# Altium schematic sheet IR_LED.SchDoc
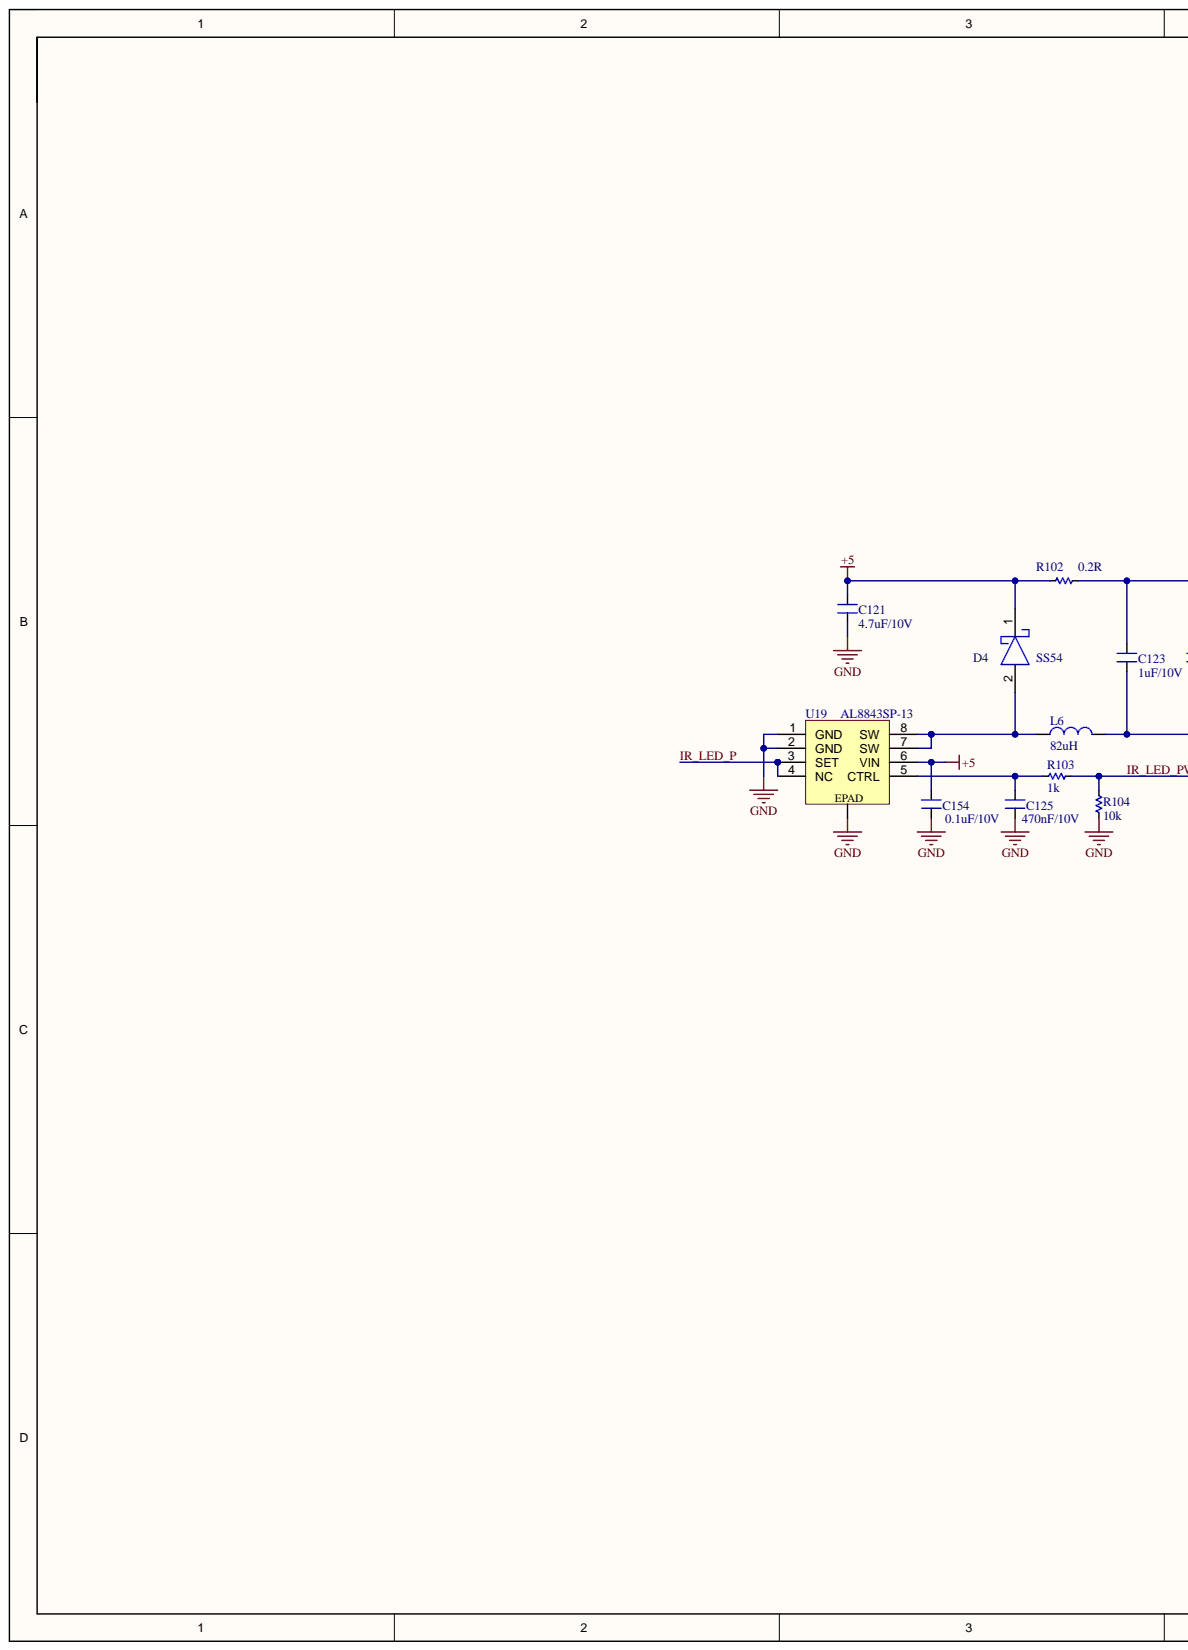
[diagram p0: IR_LED.SchDoc, left]
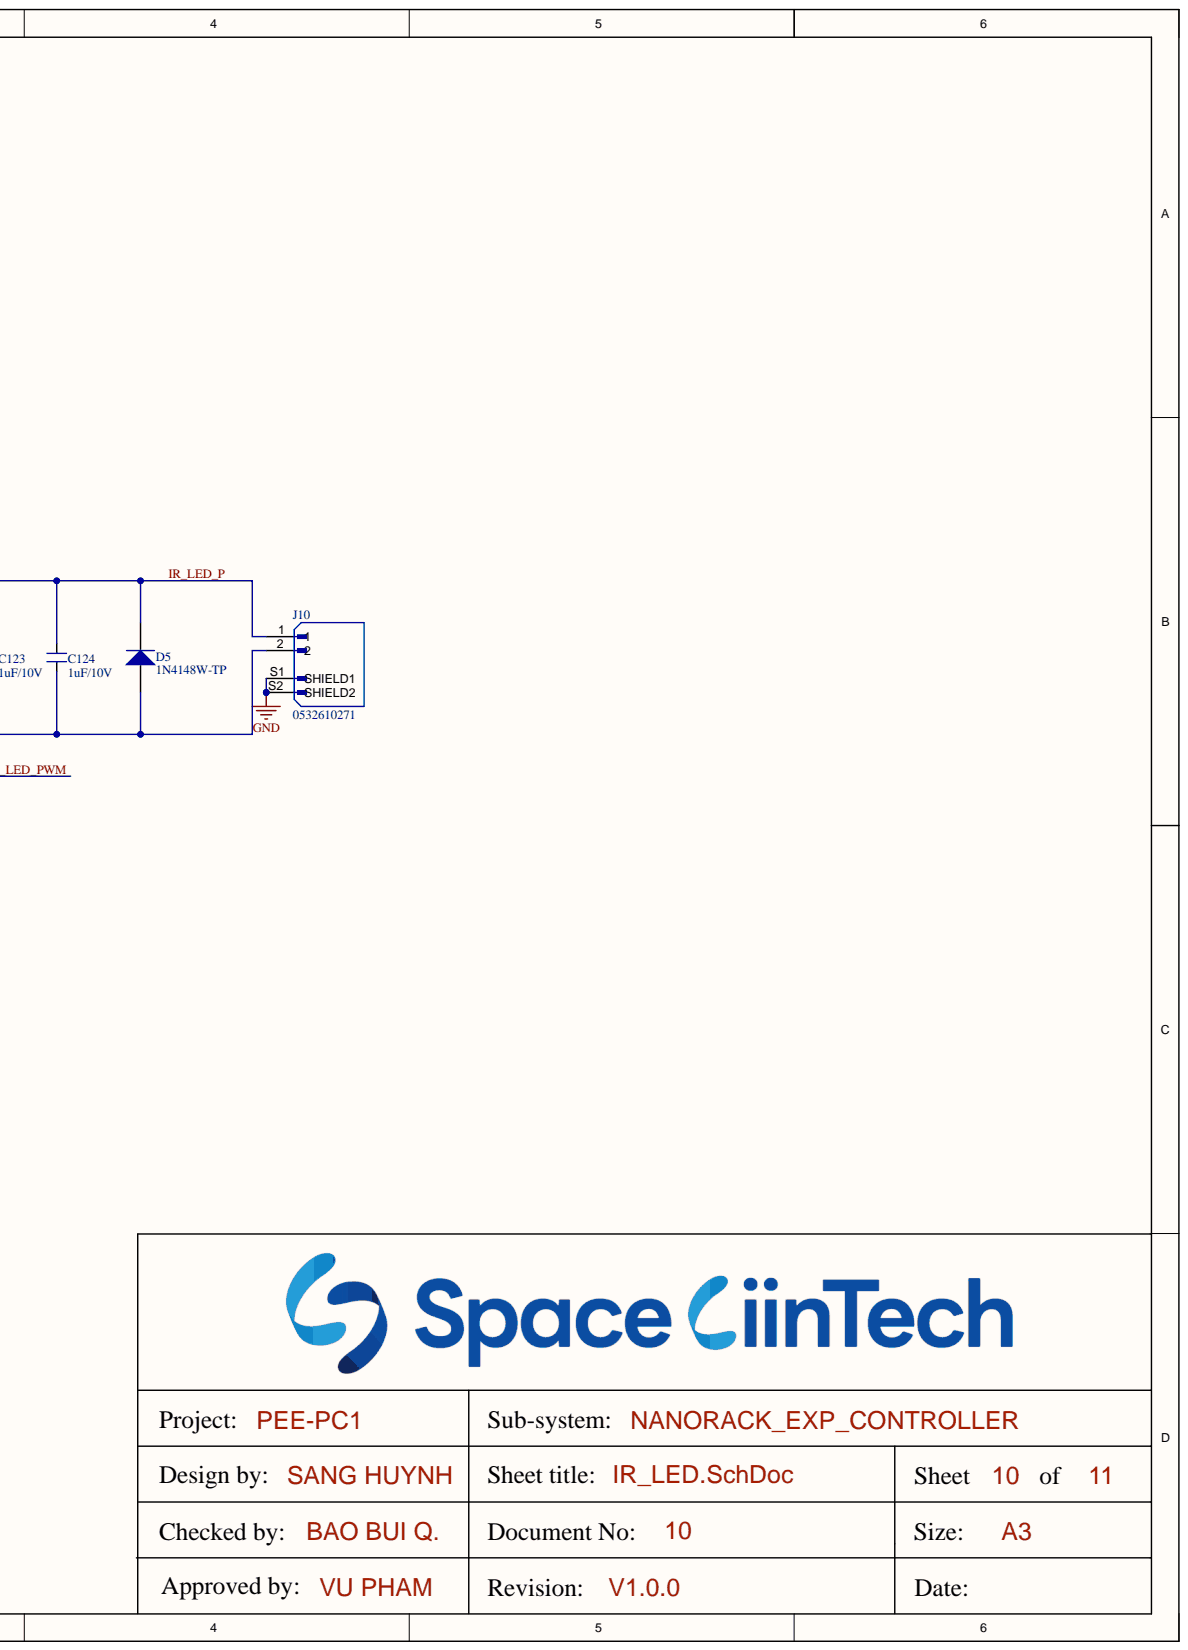
[diagram p1: IR_LED.SchDoc, right]

## Parts
C121  4.7uF/10V  [CAP_C_1608X80]
C123  1uF/10V  [CAP_C_1608X80]
C124  1uF/10V  [CAP_C_1608X80]
C125  470nF/10V  [CAP_C_1608X80]
C154  0.1uF/10V  [CAP_C_1608X80]
D4  SS54  [DO214-AC-SMA_EXP_V110]
D5  1N4148W-TP  [SOD-123-EXP-V110]
J10  0532610271  [0532610271]
L6  82uH  [L-SMD-M-4.8x4.8x2.8mm]
R102  0.2R  [RES_C_3216X70]
R103  1k  [RES_C_1608X60]
R104  10k  [RES_C_1608X60]
U19  AL8843SP-13  [SO8_EPAD]

## Nets
+5: C121.2 C154.2 D4.1 R102.2 U19.6(VIN)
GND: C121.1 C125.1 C154.1 J10.S1(SHIELD1) J10.S2(SHIELD2) R104.1 U19.1(GND) U19.2(GND) U19.EPAD
IR_LED_P: C123.2 C124.2 D5.2 J10.1 R102.1 U19.3(SET) U19.4(NC)
IR_LED_PWM: R103.2 R104.2
NetC123_1: C123.1 C124.1 D5.1 J10.2 L6.2
NetC125_2: C125.2 R103.1 U19.5(CTRL)
NetD4_2: D4.2 L6.1 U19.7(SW) U19.8(SW)

## Library data of the parts
C121 C123-C125 C154 = Capacitors: Value: 33pF; Component Kind: Standard; Library Name: Sang_library.SchLib
D4 = B360B-E3/52T: DIODE SCHOTTKY 40V 5A SMA; Component Kind: Standard; Library Name: Sang_library.SchLib
D5 = 1N4148-W-7: DIODE GEN PURP 100V 300MA SOD123; MFR: Diodes Incorporated; MPN: 1N4148W-7-F; Solder: SMT; Value: 100V/300MA; Component Kind: Standard; Library Name: Sang_library.SchLib
J10 = CONN_SMD_02: CONN HEADER SMD R/A 2POS 1.25MM; Component Kind: Standard; Library Name: EXP_HW_V2.SchLib
L6 = L-SMD-1: SRR4828A-820M; Manufacturer: Wurth Elektronik; partNo: 78477010; Inductance: 1.0 μH; Component Kind: Standard; ComponentLink1Description: Manufacturer; ComponentLink1URL: http://www.we-online.de; ComponentLink2Description: Datasheet; ComponentLink2URL: http://katalog.we-online.de/pbs/datasheet/78477010.pdf; Created Date: Nov.2015; Created by: Baojun Chen; Library Name: Sang_library.SchLib
R102 = Res-SMD: RES 0.1 OHM 1% 1W 1206  PN: PE1206FRF470R1L; Manufacturer: Generic Components; Class: Passive; Component Kind: Standard; Library Name: Library.SchLib; Published: 08/06/2000; Publisher: Altium Hobart Technology Centre
R103 R104 = Res SMD: SMD; Manufacturer: Generic Components; Class: Passive; Component Kind: Standard; Published: 08/06/2000; Library Name: Sang_library.SchLib; Publisher: Altium Hobart Technology Centre
U19 = AL8843SP-13: IC LED DRIVER RGLTR PWM 3A 8SO; Component Kind: Standard; Library Name: Sang_library.SchLib
